# Altium schematic sheet Rectifier.SchDoc(Rectifier)
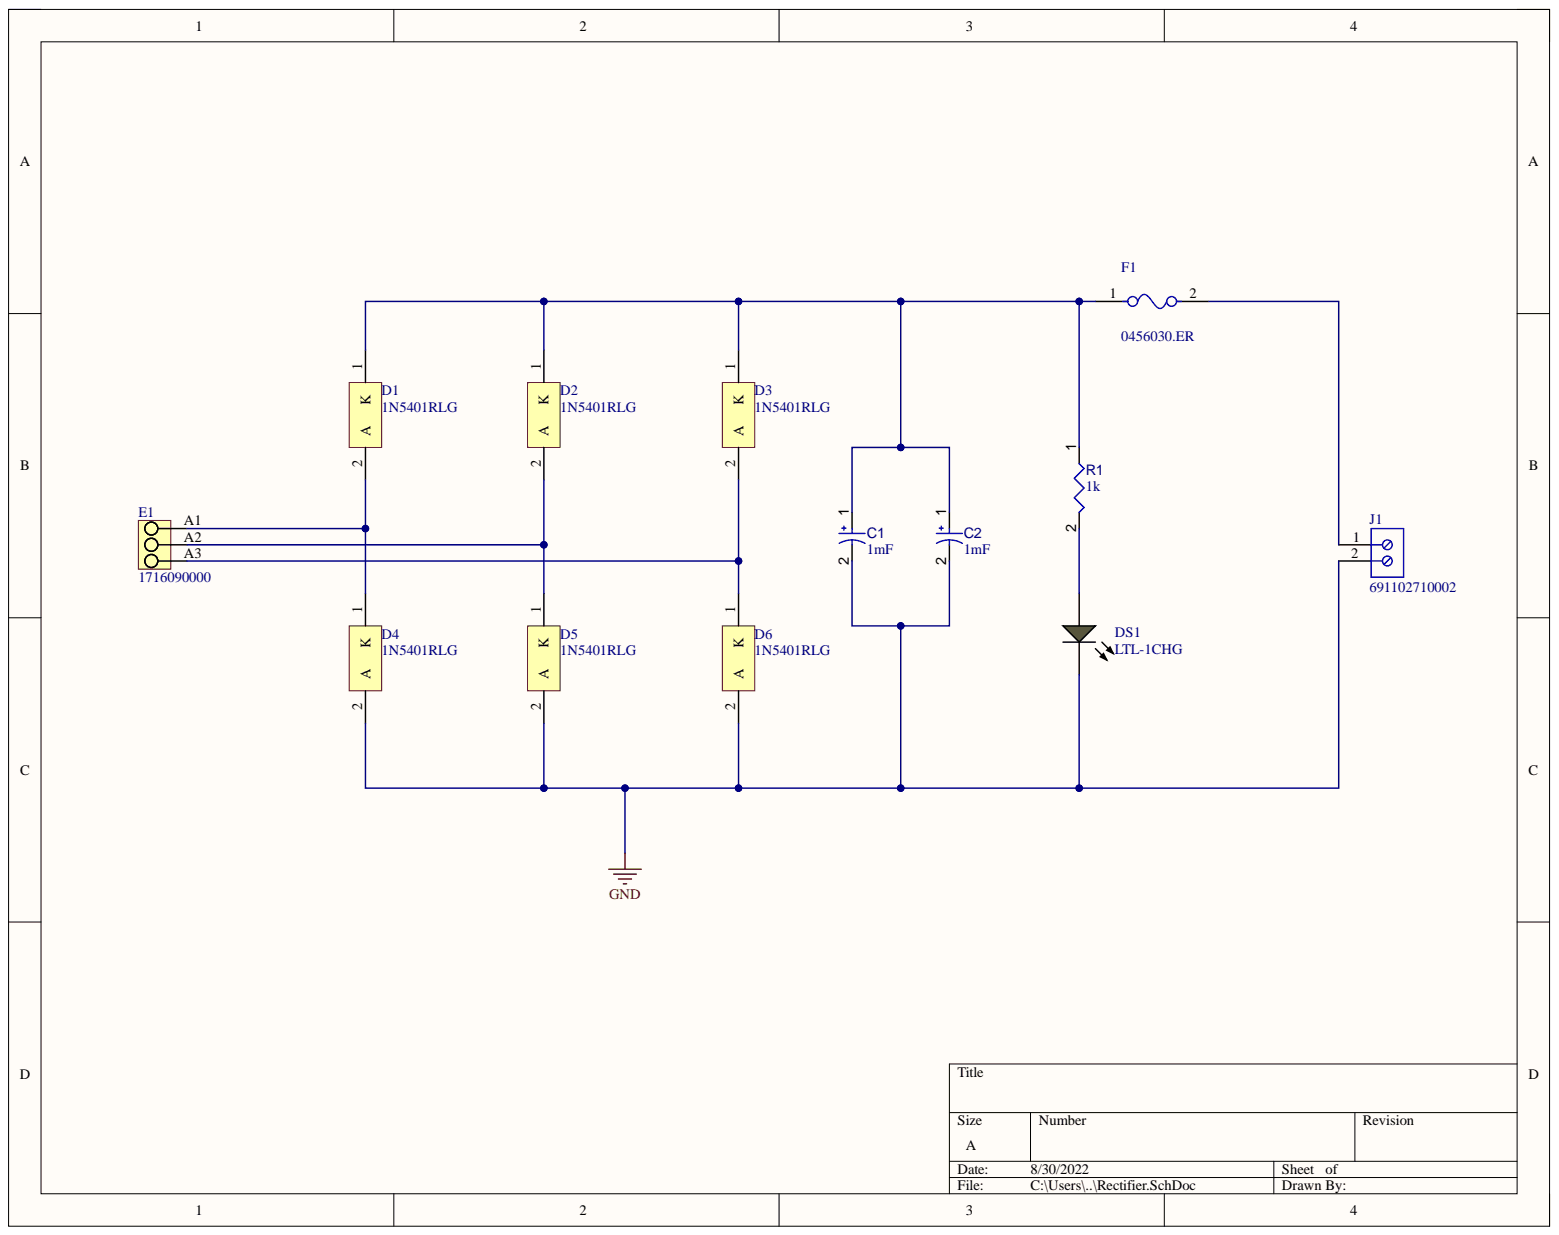
[diagram p0: Rectifier.SchDoc]

## Parts
C1  value 1mF  [CAPPR65-500-2000X1000X2200]  (hidden comment: ECA1EM102)
C2  value 1mF  [CAPPR65-500-2000X1000X2200]  (hidden comment: ECA1EM102)
D1  1N5401RLG  [ONSC-AXIAL_LEAD-2-267-05_V]
D2  1N5401RLG  [ONSC-AXIAL_LEAD-2-267-05_V]
D3  1N5401RLG  [ONSC-AXIAL_LEAD-2-267-05_V]
D4  1N5401RLG  [ONSC-AXIAL_LEAD-2-267-05_V]
D5  1N5401RLG  [ONSC-AXIAL_LEAD-2-267-05_V]
D6  1N5401RLG  [ONSC-AXIAL_LEAD-2-267-05_V]
DS1  LTL-1CHG  [LTST-LTL-1CHG-2]
E1  1716090000  [LM_5_08_90_03]
F1  0456030.ER  [FP-0456-MFG]
J1  691102710002  [691102710002]
R1  value 1k  [RESA60-630X240]  (hidden comment: MFR-25FBF52-1K)

## Nets
GND: C1.2 C2.2 D4.2 D5.2 D6.2 DS1.2 J1.2
NetC1_1: C1.1 C2.1 D1.1 D2.1 D3.1 F1.1 R1.1
NetD1_2: D1.2 D4.1 E1.A1
NetD2_2: D2.2 D5.1 E1.A2
NetD3_2: D3.2 D6.1 E1.A3
NetDS1_1: DS1.1 R1.2
NetF1_2: F1.2 J1.1

## Library data of the parts
C1 C2 = CMP-001-00023-8: Aluminum Electrolytic Capacitor, 1000 uF, +/- 20%, 25 V, -40 to 85 degC, 2-Pin THD, RoHS, Bulk; Manufacturer: Panasonic; Ripple Current: 850mA; Voltage Rating: 25V; Capacitor Type: Electrolytic; Case/Package: Radial; Component Kind: Standard; Datasheet URL: https://industrial.panasonic.com/cdbs/www-data/pdf/RDF0000/ABA0000C1218.pdf; Datasheet Version: Rev. 05, 10/2016; Diameter: 10mm; Lead Pitch: 5mm; Manufacturer URL: http://www.panasonic.com/; Max Operating Temperature: 85°C; Min Operating Temperature: -40°C; Mounting Technology: TH; Package Description: 2-Pin Radial Lead, Dia 10 mm, Height 22 mm; Package Quantity: 200; Package Reference: CAPPR65-500-2000X1000X2200; Packaging: Bulk; RoHS Compliant: True; Tolerance: 20%; Library Name: Colton's Workspace
D1-D6 = CMP-0902-00079-1: Standard Recovery Rectifier, 2-Pin Axial_Lead, Pb-Free, Tape and Reel; Manufacturer: On Semiconductor; IFSM Max (A): 200; IO(rec) Max (A): 3; VRRM Max (V): 100; Component Kind: Standard; ComponentLink1Description: Manufacturer URL; ComponentLink1URL: http://www.onsemi.com/; ComponentLink2Description: Datasheet; ComponentLink2URL: http://www.onsemi.com/pub_link/Collateral/1N5400-D.PDF; ComponentLink3Description: Package Specification; ComponentLink3URL: http://www.onsemi.com/pub_link/Collateral/267-05.PDF; DatasheetVersion: Rev. 10; Library Name: Altium Content Vault; Mounting Technology: Through Hole; PackageDescription: 2-Pin, Axial Lead, Diameter 5.05 mm; PackageReference: AXIAL_LEAD-2-267-05; PackageVersion: Rev. G, 06/2000; Packing: Tape and Reel; PartNumber: 1N5401RLG; RoHS: TRUE; Type: Standard Recovery
DS1 = CMP-007-00019-2: LED Uni-Color Green, 100 mW, 30 mA, -55 to 100 degC, 2-Pin THD, RoHS, Bulk; Manufacturer: Lite-On; Forward Current: 30mA; Forward Voltage: 2.6V; Lens Style: Dome; Luminous Intensity: 12.6mcd; Nominal Vf: 2.1V; Power: 100mW; Viewing Angle: 60degrees; Case/Package: Radial; Colour: Green; Component Kind: Standard; Datasheet URL: http://datasheet.octopart.com/LTL-1CHG-Lite-On-datasheet-143841.pdf; Datasheet Version: Mar-00; Illumination Colour: Green; Manufacturer URL: http://www.us.liteon.com/; Max Operating Temperature: 100°C; Min Operating Temperature: -55°C; Mounting Technology: TH; Package Description: 2-Pin Through Hole Device, Dia 3.8 mm; Package Quantity: 1000; Package Reference: LTL-1CHG; Packaging: Bulk; Pins: 2; RoHS Compliant: True; Library Name: Colton's Workspace
E1 = CMP-1559-01768-1: OMNIMATE Signal - series LM; Manufacturer: Weidmueller; Contact_material: Cu-Leg; Contact_surface: tinned; Insulating_material: Wemid (PA); Mounting_onto_the_PCB: Solder connection; No_of_poles: 3; Pitch_inch: 0,2 inch; Pitch_mm: 5,08 mm; Rated_current_use_group_B_UL_1059: 15 A; Rated_voltage_for_surge_voltage_class_pollution_degree_II_2: 630 V; Rated_voltage_use_group_B_UL_1059: 300 V; Short_text_for_material: LM 5.08/03/90 3.5SN OR BX; Side_termination_characteristic: closed side; Solder_pin_length_mm: 3,5 mm; Solid_max_H05_07_V_U: 2,5 mm²; Solid_min_H05_07_V_U: 0,2 mm²; UL_94_flammability_rating: V-0; Wire_cross_section_AWG_max_UL_1059: AWG 14; Wire_cross_section_AWG_min_UL_1059: AWG 24; Colour: Orange; Component Kind: Standard; ComponentLink1Description: Manufacturer URL; ComponentLink1URL: http://www.weidmueller.com/; ComponentLink2Description: URL Link1; ComponentLink2URL: http://catalog.weidmueller.com/catalog/Start.do?localeId=en&ObjectID=1716090000; Library Name: Altium Content Vault; PackageReference: LM_5_08_90_03; Packaging: Box; PartNumber: 1716090000; Qty: 500 PCS; Revision: V1
F1 = CMP-2000-05709-2: FUSE BOARD MNT 30A 125VAC 72VDC; Manufacturer: Littelfuse; Fuse Blow Speed: Fast; Fuse I2T: 81A2Sec; Fuse Interrupt Ac Current: 300A; Fuse Interrupt Dc Current: 1000A; Fuse Voltage Drop: 69.9mV; Max Fuse Ac Voltage: 125V; Max Fuse Dc Voltage: 72V; Resistance: 0.00132Ω; Value: 0.00132Ω; Automotive: No; Category: Fuse; Component Kind: Standard; ComponentLink2Description: Datasheet; ComponentLink2URL: https://www.littelfuse.com/~/media/electronics/datasheets/fuses/littelfuse_fuse_456_datasheet.pdf.pdf; Device Class L1: Circuit Protection; Device Class L2: Fuses; Device Class L3: unset; Digikey Description: FUSE BOARD MNT 30A 125VAC 72VDC; Fuse Current: 30A; Height: 3.05mm; Ipc Land Pattern Name: CAPC1008305X305; Lead Free: Yes; Library Name: Altium Content Vault; Manufacturer Part Number: 0456030.ER; Mouser Description: 456 Series Fast-Acting 125 V 30 A 10.10 x 3.05 mm NANO2® SMT Ceramic Fuse; Octopart: https://octopart.com/0456030.er-littelfuse-7502597; Package: 0456; RoHS: TRUE; Temperature: -55°C to +125°C; Temperature Range High: +125°C; Temperature Range Low: -55°C; Voltage Rating: 125V
J1 = CMP-1502-03258-1: Series 102 - 5.00 mm Horizontal Entry Modular with Pressure Clamp WR-TBL, 2 pin; Manufacturer: Wurth Elektronik; Pin Number: 2; Pitch: 5.00mm; Component Kind: Standard; Datasheet URL: http://katalog.we-online.de/em/datasheet/6911027100xx.pdf; Library Name: Altium Content Vault; Manufacturer URL: http://www.we-online.de; Mounting Technology: THT; Operating Temperature: -40to105degC; Package Reference: 691102710002; Packaging: Box; Part Number: 691102710002; Publisher: WurthElektronik
R1 = CMP-009-00048-4: Axial Resistor, 1 KOhm, +/- 1%, 250 mW, -55 to 155 degC, 2-Pin THD, RoHS, Bulk; Manufacturer: Yageo; Power: 250mW; Voltage Rating: 250V; Case/Package: Axial; Component Kind: Standard; Composition: CarbonFilm; Datasheet URL: http://www.yageo.com/documents/recent/Yageo%20LR_MFR_2013.pdf; Datasheet Version: Apr-13; Manufacturer URL: http://www.yageo.com/; Max Operating Temperature: 155°C; Min Operating Temperature: -55°C; Mounting Technology: TH; Package Description: 2-Pin Axial Lead, Dia 2.4 mm, Body Length 6.3 mm; Package Quantity: 200; Package Reference: MFR-25; Packaging: Bulk; RoHS Compliant: True; Tolerance: 1%; Library Name: Colton's Workspace
